# Altium schematic sheet Microbit built-in.SchDoc
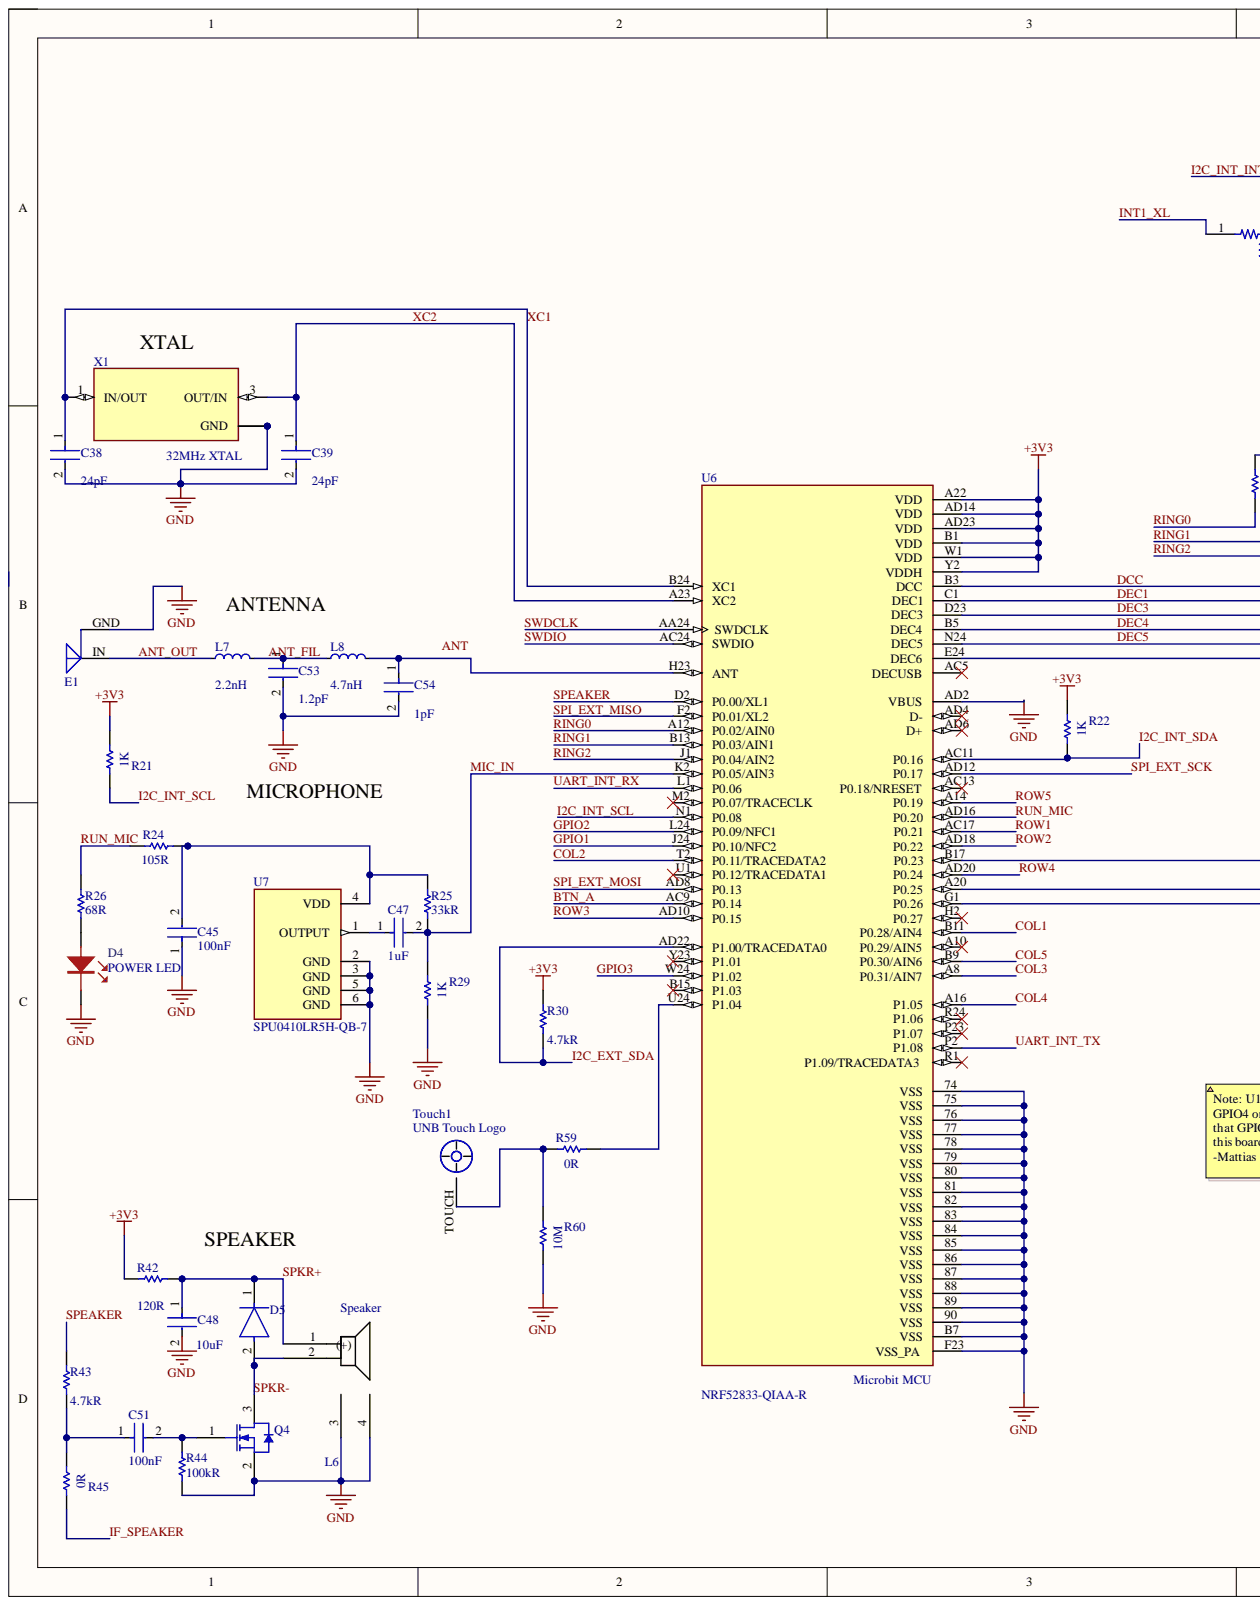
[diagram p0: Microbit built-in.SchDoc, left]
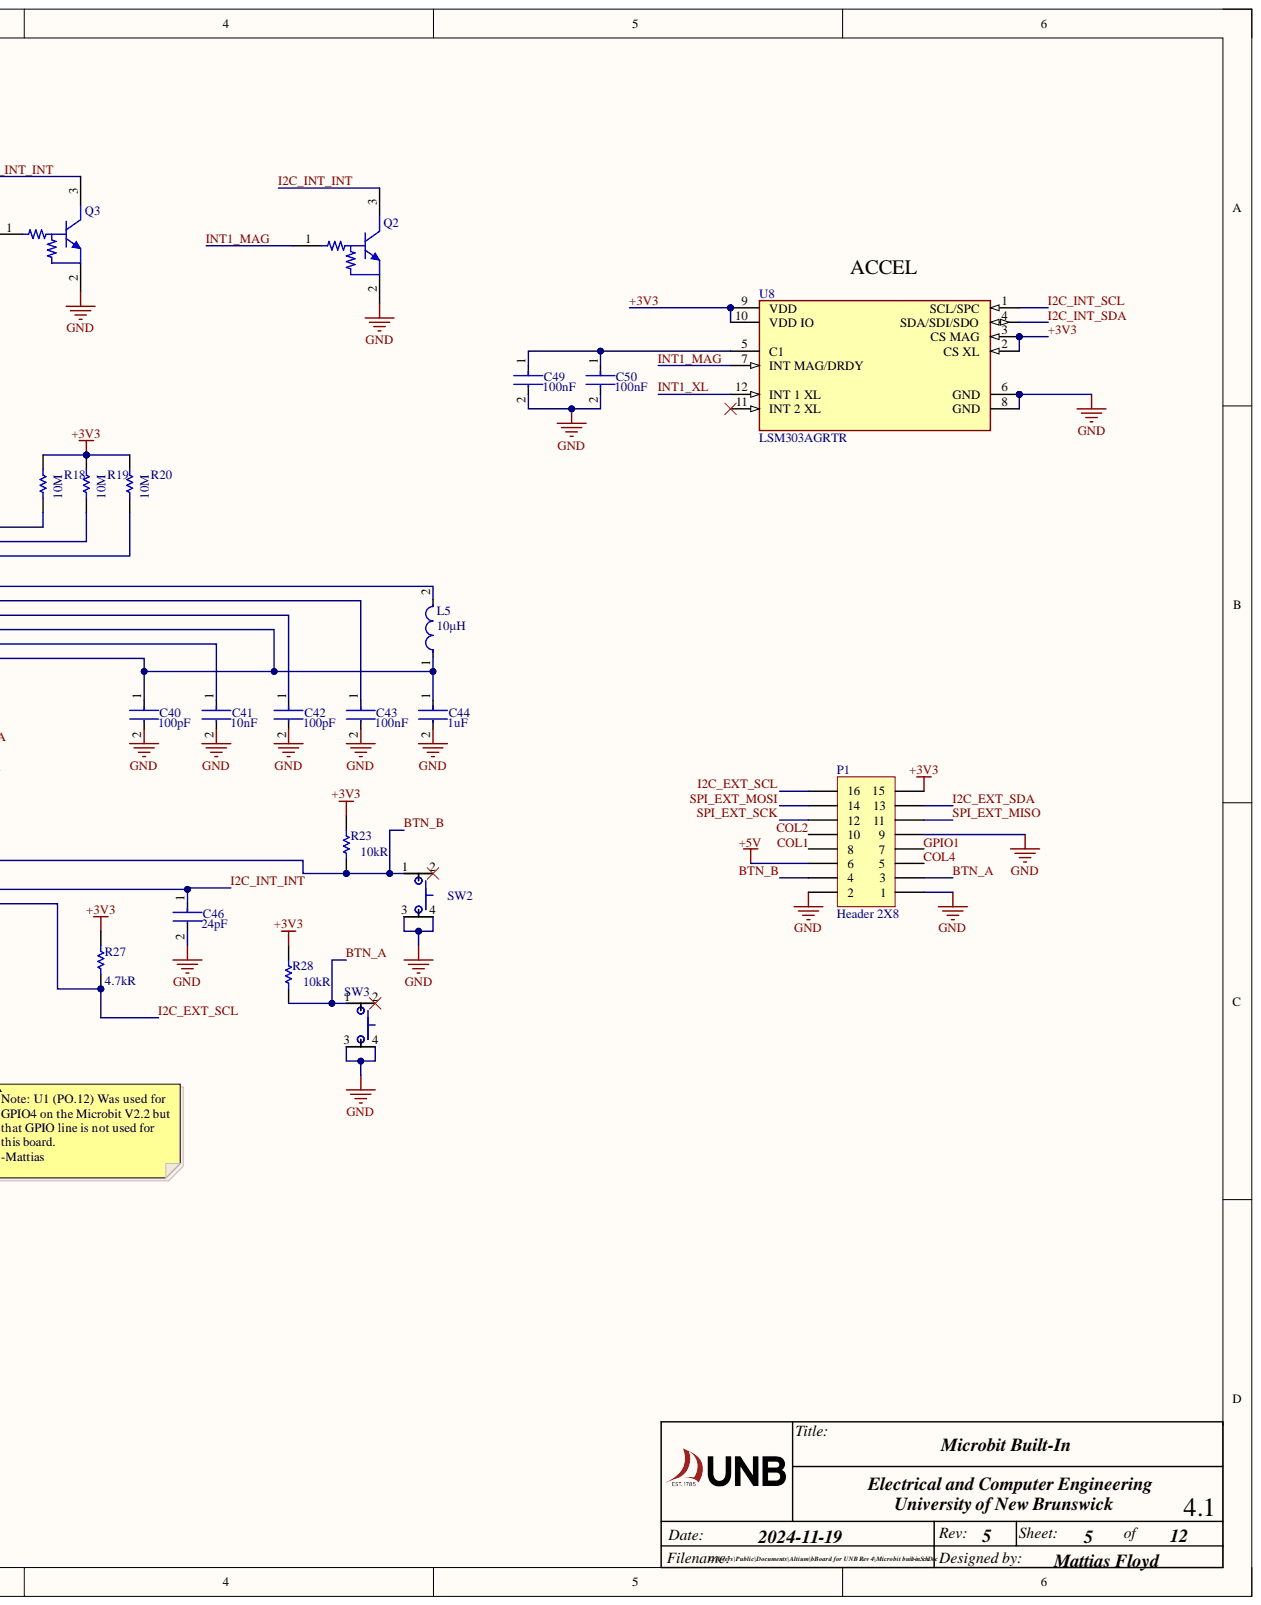
[diagram p1: Microbit built-in.SchDoc, right]

## Parts
C38  Capacitance: 24pF  [0402 CAP]  (hidden comment: CAP CC0402JRNPO9BN240)
C39  Capacitance: 24pF  [0402 CAP]  (hidden comment: CAP CC0402JRNPO9BN240)
C40  Capacitance: 100pF  [0402 CAP]  (hidden comment: CAP CL05C101JB5NNNC)
C41  Capacitance: 10nF  [0402 CAP]  (hidden comment: CAP GRM155R71E103KA01D)
C42  Capacitance: 100pF  [0402 CAP]  (hidden comment: CAP CL05C101JB5NNNC)
C43  Capacitance: 100nF  [0402 CAP]  (hidden comment: CAP GRM155R61H104KE14D)
C44  Capacitance: 1uF  [0402 CAP]  (hidden comment: CAP CC0402KRX5R5BB105)
C45  Capacitance: 100nF  [0402 CAP]  (hidden comment: CAP GRM155R61H104KE14D)
C46  Capacitance: 24pF  [0402 CAP]  (hidden comment: CAP CC0402JRNPO9BN240)
C47  Capacitance: 1uF  [0402 CAP]  (hidden comment: CAP CC0402KRX5R5BB105)
C48  Capacitance: 10uF  [0603 CAP]  (hidden comment: CAP GRM188R60J106ME47D)
C49  Capacitance: 100nF  [0402 CAP]  (hidden comment: CAP GRM155R61H104KE14D)
C50  Capacitance: 100nF  [0402 CAP]  (hidden comment: CAP GRM155R61H104KE14D)
C51  Capacitance: 100nF  [0402 CAP]  (hidden comment: CAP GRM155R61H104KE14D)
C53  Capacitance: 1.2pF  [0402 CAP]  (hidden comment: CAP GJM1555C1H1R2JB01D)
C54  Capacitance: 1pF  [0402 CAP]  (hidden comment: CAP GCM1555C1H1R0JA16D)
D4  POWER LED  [LED_0603_RED]
D5  DIODE BAS16LD,315  [FP-SOD882D-MFG]  (value not drawn)
E1  ANT-2.45-CHP-T, 2.4GHz, 802.11, Bluetooth, SMD  [PCB Antenna]  (value not drawn)
L5  10μH  [FP-LQM18FN_00-IPC_A]
L6  MLT-8530  [MLT-8530]  (value not drawn)
L7  Inductance-Nom (L): 2.2nH  [0402 Inductor]  (hidden comment: L AISC-0402-2N2J-T)
L8  Inductance-Nom (L): 4.7nH  [0402 Inductor]  (hidden comment: L CW100505-4N7J)
P1  Header 2X8  [2X8 HDR - 0901512116]
Q2  DTC143EET1G  [ONSC-SC-75-3-463-01_V]  (value not drawn)
Q3  DTC143EET1G  [ONSC-SC-75-3-463-01_V]  (value not drawn)
Q4  BSS816NW  [INF-PG-SOT-323_L]  (value not drawn)
R18  RES MCWR04X1005FTL  [0402 RES]  (value not drawn)
R19  RES MCWR04X1005FTL  [0402 RES]  (value not drawn)
R20  RES MCWR04X1005FTL  [0402 RES]  (value not drawn)
R21  MC00625W040211K  [0402 RES]  (value not drawn)
R22  MC00625W040211K  [0402 RES]  (value not drawn)
R23  Resistance: 10kR  [0402 RES]  (hidden comment: RES MC00625W0402110K)
R24  Resistance: 105R  [0402 RES]  (hidden comment: RES ERJ-2RKF1050X)
R25  Resistance: 33kR  [0402 RES]  (hidden comment: RES RC0402JR-0733KL)
R26  Resistance: 68R  [0402 RES]  (hidden comment: RES RC0402JR-0768RL)
R27  Resistance: 4.7kR  [0402 RES]  (hidden comment: RES RC0402FR-074K7L)
R28  Resistance: 10kR  [0402 RES]  (hidden comment: RES MC00625W0402110K)
R29  MC00625W040211K  [0402 RES]  (value not drawn)
R30  Resistance: 4.7kR  [0402 RES]  (hidden comment: RES RC0402FR-074K7L)
R42  RES RC0603JR-07120RL  [0603 RESISTOR]  (value not drawn)
R43  Resistance: 4.7kR  [0402 RES]  (hidden comment: RES RC0402FR-074K7L)
R44  Resistance: 100kR  [0402 RES]  (hidden comment: RES MCWR04X1003FTL)
R45  RES MCSR04X000PTL  [0402 RES]  (value not drawn)
R59  RES MCSR04X000PTL  [0402 RES]  (value not drawn)
R60  RES MCWR04X1005FTL  [0402 RES]  (value not drawn)
SW2  SW ADTSM62KVTR  [SW_FSM4JSMA]  (value not drawn)
SW3  SW ADTSM62KVTR  [SW_FSM4JSMA]  (value not drawn)
Touch1  UNB Logo  [UNB Logo]
U6  NRF52833-QIAA-R  [XCVR_NRF52833-QIAA-R]
U7  SPU0410LR5H-QB-7  [XDCR_SPU0410LR5H-QB]
U8  LSM303AGRTR  [FP-LGA-12-8487206-MFG]
X1  32MHz XTAL  [XTAL_ECS-320-16-33B-CKM-TR]

## Nets
+5V: P1.6
GND: C38.2 C39.2 C40.2 C41.2 C42.2 C43.2 C44.2 C45.1 C46.2 C48.2 C49.2 C50.2 C53.2 C54.2 D4.1 E1.GND P1.1 P1.2 P1.9 Q2.2 Q3.2 Q4.2 R29.1 R44.1 R60.1 SW2.3 SW2.4 SW3.3 SW3.4 U6.74(VSS) U6.75(VSS) U6.76(VSS) U6.77(VSS) U6.78(VSS) U6.79(VSS) U6.80(VSS) U6.81(VSS) U6.82(VSS) U6.83(VSS) U6.84(VSS) U6.85(VSS) U6.86(VSS) U6.87(VSS) U6.88(VSS) U6.89(VSS) U6.90(VSS) U6.AD2(VBUS) U6.B7(VSS) U6.F23(VSS_PA) U7.2(GND) U7.3(GND) U7.5(GND) U7.6(GND) U8.6(GND) U8.8(GND) X1.2(GND) X1.4
+3V3: P1.15 R18.2 R19.2 R20.2 R21.2 R22.2 R23.2 R27.2 R28.2 R30.2 R42.2 U6.A22(VDD) U6.AD14(VDD) U6.AD23(VDD) U6.B1(VDD) U6.W1(VDD) U6.Y2(VDDH) U8.2(CS_XL) U8.3(CS_MAG) U8.9(VDD) U8.10(VDD_IO)
ANT_FIL: C53.1 L7.2 L8.1
ANT_OUT: E1.IN L7.1
BTN_A: P1.3 R28.1 SW3.1 U6.AC9(P0.14)
BTN_B: P1.4 R23.1 SW2.1 U6.B17(P0.23)
COL1: P1.8 U6.B11(P0.28/AIN4)
COL2: P1.10 U6.T2(P0.11/TRACEDATA2)
COL3: U6.A8(P0.31/AIN7)
COL4: P1.5 U6.A16(P1.05)
COL5: U6.B9(P0.30/AIN6)
DCC: L5.2 U6.B3(DCC)
DEC1: C43.1 U6.C1(DEC1)
DEC3: C42.1 U6.D23(DEC3)
DEC4: C40.1 C44.1 L5.1 U6.B5(DEC4) U6.E24(DEC6)
DEC5: C41.1 U6.N24(DEC5)
GPIO1: P1.7 U6.J24(P0.10/NFC2)
GPIO2: U6.L24(P0.09/NFC1)
GPIO3: U6.W24(P1.02)
I2C_EXT_SCL: P1.16 R27.1 U6.G1(P0.26)
I2C_EXT_SDA: P1.13 R30.1 U6.AD22(P1.00/TRACEDATA0)
I2C_INT_INT: C46.1 Q2.3 Q3.3 U6.A20(P0.25)
I2C_INT_SCL: R21.1 U6.N1(P0.08) U8.1(SCL/SPC)
I2C_INT_SDA: R22.1 U6.AC11(P0.16) U8.4(SDA/SDI/SDO)
IF_SPEAKER: R45.1
INT1_MAG: Q2.1 U8.7(INT_MAG/DRDY)
INT1_XL: Q3.1 U8.12(INT_1_XL)
MIC_IN: C47.2 R25.1 R29.2 U6.K2(P0.05/AIN3)
RING0: R18.1 U6.A12(P0.02/AIN0)
RING1: R19.1 U6.B13(P0.03/AIN1)
RING2: R20.1 U6.J1(P0.04/AIN2)
ROW1: U6.AC17(P0.21)
ROW2: U6.AD18(P0.22)
ROW3: U6.AD10(P0.15)
ROW4: U6.AD20(P0.24)
ROW5: U6.A14(P0.19)
RUN_MIC: R24.2 R26.2 U6.AD16(P0.20)
SPEAKER: R43.2 U6.D2(P0.00/XL1)
SPI_EXT_MISO: P1.11 U6.F2(P0.01/XL2)
SPI_EXT_MOSI: P1.14 U6.AD8(P0.13)
SPI_EXT_SCK: P1.12 U6.AD12(P0.17)
SPKR+: C48.1 D5.1 L6.1((+)) R42.1
SPKR-: D5.2 L6.2 Q4.3
SWDCLK: U6.AA24(SWDCLK)
SWDIO: U6.AC24(SWDIO)
UART_INT_RX: U6.L1(P0.06)
UART_INT_TX: U6.P2(P1.08)
XC1: C38.1 U6.B24(XC1) X1.1(IN/OUT)
XC2: C39.1 U6.A23(XC2) X1.3(OUT/IN)
NetC45_2: C45.2 R24.1 R25.2 U7.4(VDD)
NetC47_1: C47.1 U7.1(OUTPUT)
NetC49_1: C49.1 C50.1 U8.5(C1)
NetC51_1: C51.1 R43.1 R45.2
NetC51_2: C51.2 Q4.1 R44.2
NetC54_1: C54.1 L8.2 U6.H23(ANT)
NetD4_2: D4.2 R26.1
NetR59_1: R59.1 R60.2 Touch1.TOUCH
NetR59_2: R59.2 U6.U24(P1.04)
ANT:

Not connected: L6 3 4; SW2 2; SW3 2; U6 A10(P0.29/AIN5) AC5(DECUSB) AC13(P0.18/NRESET) AD4(D-) AD6(D+) B15(P1.03) H2(P0.27) M2(P0.07/TRACECLK) P23(P1.07) R1(P1.09/TRACEDATA3) R24(P1.06) U1(P0.12/TRACEDATA1) Y23(P1.01); U8 11(INT_2_XL)
